# Altium schematic sheet Hbrygga.SchDoc(Hbrygga)
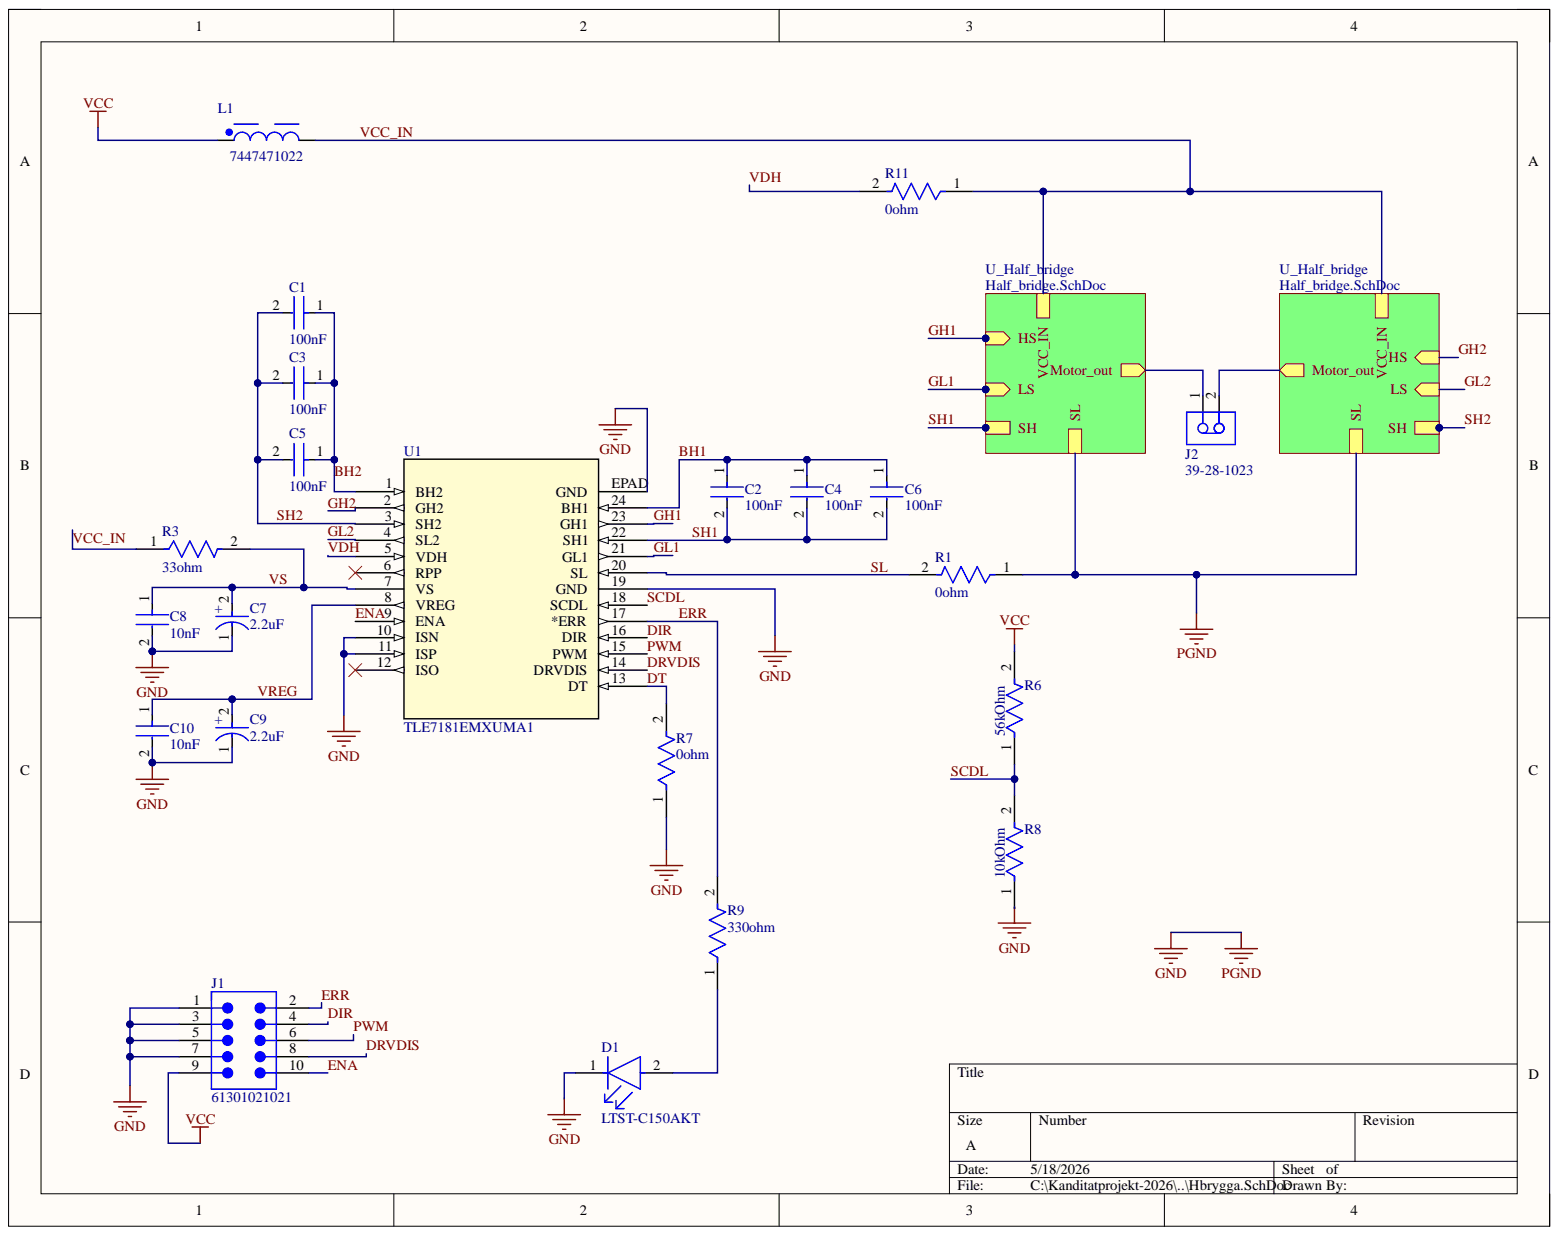
[diagram p0: Hbrygga.SchDoc]

## Parts
C1  100nF  [FP-C1206C-EB-MFG]
C2  100nF  [FP-C1206C-EB-MFG]
C3  100nF  [FP-C1206C-EB-MFG]
C4  100nF  [FP-C1206C-EB-MFG]
C5  100nF  [FP-C1206C-EB-MFG]
C6  100nF  [FP-C1206C-EB-MFG]
C7  2.2uF  [FP-RAD-TH-D_5_0_5-L_11_1-MFG]
C8  10nF  [FP-C0805C-DN-MFG]
C9  2.2uF  [FP-RAD-TH-D_5_0_5-L_11_1-MFG]
C10  10nF  [FP-C0805C-DN-MFG]
D1  LTST-C150AKT  [FP-LTST-C150AKT-MFG]
J1  61301021021  [61301021021]
J2  39-28-1023  [MOLX-39-28-1023-2_V]
L1  7447471022  [WE-TIS_1111]
R1  0ohm  [FP-RC3216-IPC_C]
R3  33ohm  [FP-CR1206-IPC_B]
R6  56kOhm  [FP-MCR10-IPC_C]
R7  0ohm  [FP-RC3216-IPC_C]
R8  10kOhm  [FP-MCR10-IPC_C]
R9  330ohm  [FP-RK73G2B-MFG]
R11  0ohm  [FP-RC3216-IPC_C]
U1  TLE7181EMXUMA1  [PG-SSOP-4_INF]

## Nets
GND: C7.1 C8.2 C9.1 C10.2 D1.1 J1.1 J1.3 J1.5 J1.7 R1.1 R7.1 R8.1 U1.10(ISN) U1.11(ISP) U1.19(GND) U1.EPAD(GND)
VCC: J1.9 L1.1 R6.2
BH1: C2.1 C4.1 C6.1 U1.24(BH1)
BH2: C1.1 C3.1 C5.1 U1.1(BH2)
DIR: J1.4 U1.16(DIR)
DRVDIS: J1.8 U1.14(DRVDIS)
DT: R7.2 U1.13(DT)
ENA: J1.10 U1.9(ENA)
ERR: J1.2 R9.2 U1.17(*ERR)
GH1: U1.23(GH1)
GH2: U1.2(GH2)
GL1: U1.21(GL1)
GL2: U1.4(SL2)
PWM: J1.6 U1.15(PWM)
SCDL: R6.1 R8.2 U1.18(SCDL)
SH1: C2.2 C4.2 C6.2 U1.22(SH1)
SH2: C1.2 C3.2 C5.2 U1.3(SH2)
SL: R1.2 U1.20(SL)
VCC_IN: L1.2 R3.1 R11.1
VDH: R11.2 U1.5(VDH)
VREG: C9.2 C10.1 U1.8(VREG)
VS: C7.2 C8.1 R3.2 U1.7(VS)
NetD1_2: D1.2 R9.1
NetJ2_1: J2.1
NetJ2_2: J2.2
NetU1_6: U1.6(RPP)
NetU1_12: U1.12(ISO)

## Library data of the parts
C1-C6 = CMP-03020-008411-1: C1206 0.10 μF U2J 2.00% 16 V; Manufacturer: KEMET; Manufacturer Part Number: C1206C104G4JACAUTO; Automotive Grade: Grade 0; Capacitance: 0.10 μF; Material: Ceramic; Temperature Coefficient: 15%; Tolerance: 0.02; Value: 0.10 μF; Voltage Rating: 16 V; Automotive: Yes; Category: Cap; Component Kind: Standard; ComponentLink2Description: Datasheet; ComponentLink2URL: https://content.kemet.com/datasheets/KEM_C1007_X8R_ULTRA_150C_SMD.pdf; Device Class L1: Passive Components; Device Class L2: Capacitors; Device Class L3: Ceramic Capacitors; Height: 0.88mm; Ipc Land Pattern Name: CAPC320160X78; Lead Free: Yes; Library Name: Altium Content Vault; Octopart: https://octopart.com/c1206c104g4jacauto-kemet-84732513; Package: C1206C-EB; RoHS: TRUE; Temperature: -55°C to +150°C; Temperature Characteristic: U2J; Temperature Range High: +150°C; Temperature Range Low: -55°C
C7 C9 = CMP-05427-000193-1: Aluminum Electrolytic Capacitors (Radial Lead Type) 2.2uF ±20% 100V; Dielectric Material: Aluminium; Dissipation Factor: 0.1%; Lead Diameter: 0.5mm; Lead/Base Style: Straight; Leakage Current: 3uA; Life (Hours): 2000Hour; Ripple Current: 30mA; Voltage: 63V; Capacitance: 2.2uF; Case/Package: Radial; Component Kind: Standard; Construction: RADIAL; Contact Plating: Tin; Diameter: 5mm; Height: 11mm; Height - Seated (Max): 0.472inch; Lead Free: Lead Free; Lead Pitch: 2mm; Length: 11mm; Library Name: Altium Content Vault; Max Operating Temperature: 85°C; Min Operating Temperature: -40°C; Mount: Through Hole; Number of Pins: 2; Package Quantity: 200; Packaging: Bulk; Radiation Hardening: No; REACH SVHC: No SVHC; Ripple Current (AC): 30mA; RoHS Compliant: Yes; Series: M; Termination: Radial; Tolerance: 20%; Voltage Rating: 100V; Voltage Rating (DC): 100V
C8 C10 = CMP-03020-028549-1: C0805 10,000 pF X8R 30ppm/°C 10.00% 50 V; Manufacturer: KEMET; Manufacturer Part Number: C0805C103K5HAC; Material: Ceramic; Tolerance: 0.1; Voltage Rating: 50 V; Automotive: No; Capacitance: 10,000 pF; Category: Cap; Component Kind: Standard; ComponentLink2Description: Datasheet; ComponentLink2URL: https://content.kemet.com/datasheets/KEM_C1003_C0G_SMD.pdf; Device Class L1: Passive Components; Device Class L2: Capacitors; Device Class L3: Ceramic Capacitors; Height: 0.88mm; Ipc Land Pattern Name: CAPC200125X78; Lead Free: Yes; Library Name: Altium Content Vault; Mouser Description: Multilayer Ceramic Capacitors MLCC - SMD/SMT 50volts 0.01uF 10% ULTRA STABLE X8R; Octopart: https://octopart.com/c0805c103k5hac-kemet-74776188; Package: C0805C-DN; RoHS: TRUE; Temperature: -55°C to +125°C; Temperature Characteristic: X8R; Temperature Coefficient: 30ppm/°C; Temperature Range High: +125°C; Temperature Range Low: -55°C; Value: 10,000 pF
D1 = CMP-22017-000004-1: LED AMBER CLEAR SMD; Manufacturer: Lite-On; Forward Current: 30mA; Forward Voltage: 2.1V; Led Orientation: Top View; Luminous Intensity: 6mcd; Peak Emmision Wavelength: 610nm; Power Dissipation: 100mW; Reverse Voltage: 5V; Viewing Angle: 130°; Wavelength: 602nm; Automotive: No; Category: Diode; Colour: Amber; Component Kind: Standard; ComponentLink2Description: Datasheet; ComponentLink2URL: https://media.digikey.com/pdf/Data%20Sheets/Lite-On%20PDFs/LTST-C150AKT.pdf; Device Class L1: Optoelectronics; Device Class L2: LEDs; Device Class L3: unset; Digikey Description: LED AMBER CLEAR SMD; Height: 1.2mm; Lead Free: Yes; Library Name: Altium Content Vault; Manufacturer Part Number: LTST-C150AKT; Mouser Description: Standard LEDs - SMD Amber Clear 602nm; Octopart: https://octopart.com/ltst-c150akt-lite-on-549804; Package: 0; RoHS: TRUE; Temperature: -55°C to +85°C; Temperature Range High: +85°C; Temperature Range Low: -55°C
J1 = CMP-1502-01083-4: THT Angled Pin Header WR-PHD, Pitch 2.54 mm, Dual Row, 10 pins; Connector Type: Male Pin; Contact Resistance: 20mΩ; Current Rating: 3A; Gender: Male; Insulation: Insulated; Insulation Resistance: 1GΩ; Number of Contacts: 10; Operating Supply Voltage: 250V; Pitch: 2.54mm; Color: Black; Component Kind: Standard; Contact Gender: Male; Contact Material: Copper; Contact Mating Length: 5.9944mm; Contact Plating: Gold; Flammability Rating: UL94 V-0; Height: 2.54mm; Housing Material: PBT; Lead Pitch: 2.54mm; Length: 12.7mm; Library Name: Altium Content Vault; Max Operating Temperature: 125°C; Min Operating Temperature: -40°C; Mount: Through Hole; Number of Pins: 10; Number of Positions: 10; Number of Rows: 2; Orientation: Right Angle; Packaging: Bulk; RoHS: Compliant; Row Spacing: 2.54mm; Termination: Through Hole; Voltage Rating: 250V; Width: 12.7mm
J2 = CMP-2000-05244-1: Female Header, Pitch 4.2 mm, 1 x 2 Position, Height 12.8 mm, Tail Length 3.5 mm, -40 to 105 degC, RoHS; Manufacturer: Molex; Connector Body Material: –; Connector Mounting: PCB; Connector Type: RectangularPower; Contact Termination: Solder; Current Rating: 13A; Mounting Orientation: Straight; No. of Contacts: 4; No. of Poles: 2; No. of Rows: 1; Pitch Spacing: 4.2mm; Voltage Rating V AC: 600V; Working Voltage: 250V; Colour: Clear; Component Kind: Standard; Connector Insert Type: Header; Connector Mounting Orientation: PCB; Contact Gender: –; Contact Material: Brass; Contact Plating: Tin; Datasheet URL: https://datasheet.ciiva.com/11746/0900766b80460d9e-11746456.pdf; Datasheet Version: Rev. F, 05/2004; Flammability Rating: UL94V-2; Gender: Header; Lead Spacing: 4.2mm; Library Name: Altium Content Vault; Manufacturer URL: http://www.molex.com/; Mounting Type: PCBoard; MSL: –; No. of Ways: 2; Series: Mini-FitJr; SVHC: –; Termination Method: Solder
L1 = CMP-1406-00024-3: Inductor Power Shielded Wirewound 2.2uH 20% 1KHz Ferrite 8.2A 8.8mOhm DCR RDL Tray; DC Resistance (DCR): 7.5mΩ; Frequency: 75MHz; Inductance: 2.2µH; Lead Diameter: 650µm; Saturation Current: 10A; Ambient Temperature Range High: 85°C; Ambient Temperature Range Low: -40°C; Case/Package: Radial; Component Kind: Standard; Composition: Wirewound; Core Material: Ferrite; Current Rating: 8.2A; Diameter: 11mm; Features: Shielded; Height: 11.5mm; Height - Seated (Max): 11.5062mm; Inductance Tolerance: 20%; Lead Pitch: 5mm; Length: 11mm; Library Name: Altium Content Vault; Max DC Current: 8.2A; Max Operating Temperature: 125°C; Min Operating Temperature: -40°C; Mount: Radial, Through Hole; Number of Pins: 2; REACH SVHC: Unknown; RMS Current (Irms): 8.2A; RoHS: Compliant; Self Resonant Frequency: 75MHz; Series Resistance: 7.5mΩ; Shielding: Shielded; Termination: Radial; Test Frequency: 1kHz; Tolerance: 20%; Width: 11mm
R1 R7 R11 = CMP-13261-001409-1: Res Thick Film 1206 0Ω 5% 0.25W ±300ppm/°C Molded SMD T/R; Max Power Dissipation: 250mW; Resistance: 0mR; Voltage Rating (DC): 200V; Case Code (Imperial): 1206; Case Code (Metric): 3216; Case/Package: 1206; Component Kind: Standard; Composition: Thick Film; Contact Plating: Tin; Depth: 1.6mm; Features: Moisture Resistant; Height: 0.026inch; Lead Free: Lead Free; Length: 3.2mm; Library Name: Altium Content Vault; Max Operating Temperature: 155°C; Military Standard: Not; Min Operating Temperature: -55°C; Mount: Surface Mount; Number of Terminations: 2; Packaging: Tape and Reel; Power Rating: 250mW; Radiation Hardening: No; Tolerance: 5%; Width: 0.063inch
R3 = CMP-07231-004242-1: Thick Film Chip Resistors 1206 33Ω 0.25W 1% 100ppm/°C; Max Power Dissipation: 250mW; Resistance: 33R; Voltage Rating: 200V; Case Code (Imperial): 1206; Case Code (Metric): 3216; Case/Package: 1206; Component Kind: Standard; Composition: Thick Film; Contact Plating: Tin; Depth: 1.6mm; Features: Moisture Resistant; Height: 0.03inch; Length: 3.2mm; Library Name: Altium Content Vault; Max Operating Temperature: 155°C; Military Standard: Not; Min Operating Temperature: -55°C; Mount: Surface Mount; Number of Terminations: 2; Package Quantity: 5000; Packaging: Tape and Reel; Power Rating: 250mW; Radiation Hardening: No; Temperature Coefficient: 100ppm/°C; Termination: SMD/SMT; Thickness: 610um; Tolerance: 1%; Width: 1.6mm
R6 = CMP-08839-017707-1: Thick Film Chip Resistor, 0805, 56kΩ, 5%, 200ppm/°C, 0.125W, 150V; Max Power Dissipation: 125mW; Resistance: 56kR; Case Code (Imperial): 0805; Case Code (Metric): 2012; Case/Package: 0805; Component Kind: Standard; Features: Automotive AEC-Q200; Height: 0.024inch; Lead Free: Lead Free; Length: 0.079inch; Library Name: Altium Content Vault; Number of Terminations: 2; Package Quantity: 5000; Packaging: Tape and Reel; Resistor Type: Thick Film; RoHS Compliant: Yes; Series: MCR10; Temperature Coefficient: 200ppm/°C; Tolerance: 5%; Voltage Rating (DC): 150V; Width: 0.049inch
R8 = CMP-08839-006955-1: Thick Film Chip Resistor, 0805, 10kΩ, 1%, 100ppm/°C, 0.125W, 150V; Max Power Dissipation: 125mW; Resistance: 10kR; Case/Package: 0805; Component Kind: Standard; Height: 0.024inch; Length: 0.079inch; Library Name: Altium Content Vault; Max Operating Temperature: 155°C; Min Operating Temperature: -55°C; Number of Terminations: 2; Package Quantity: 10000; Packaging: Tape and Reel; Resistor Type: Thick Film; Series: MCR; Temperature Coefficient: 100ppm/°C; Tolerance: 1%; Width: 0.049inch
R9 = CMP-04015-101441-1: 330Ω ±0.25% 0.25W Chip Resistor 1206 Thick Film AEC-Q200 Qualified; Component Kind: Standard; Library Name: Altium Content Vault; RoHS Compliant: Yes
U1 = TLE7181EMXUMA1: It incorporates several protection features such as over current and short circuit detection as well as under-, over voltage and over temperature diagnosis. Typical applications are fans, pumps and electric power steering. The MOTIXâ„¢ TLE7181EM is designed for a 12V power net.; Manufacturer_Name: Infineon; Component Kind: Standard; Datasheet: https://www.infineon.com/dgdl/Infineon-TLE7181EM-DS-v01_01-en.pdf?fileId=db3a30432f5008fe012f5440330e3991; Library Name: TLE7181EMXUMA1.SchLib; Manufacturer_Part_Number: TLE7181EMXUMA1; RefDes: RefDes; Type: Type; Copyright: Copyright (C) 2026 Ultra Librarian. All rights reserved.
